# Altium schematic sheet Shutdown_Final.SchDoc(Shutdown_Final)
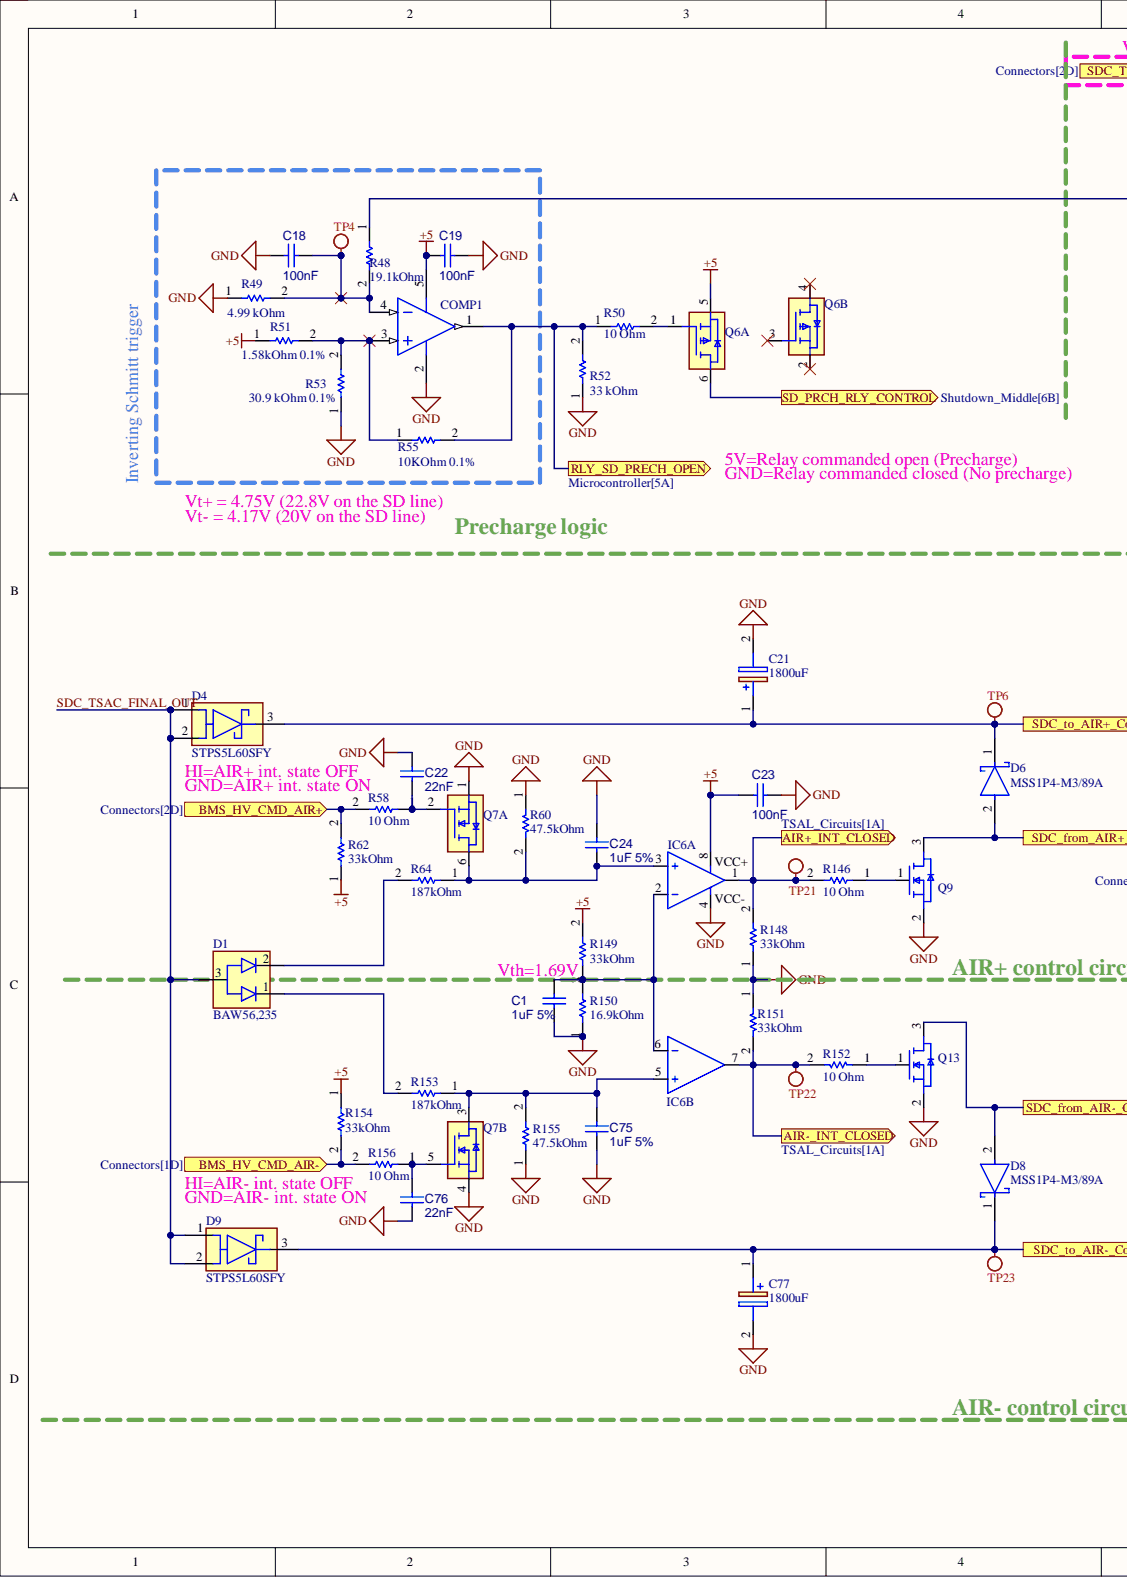
[diagram p0: Shutdown_Final.SchDoc, left]
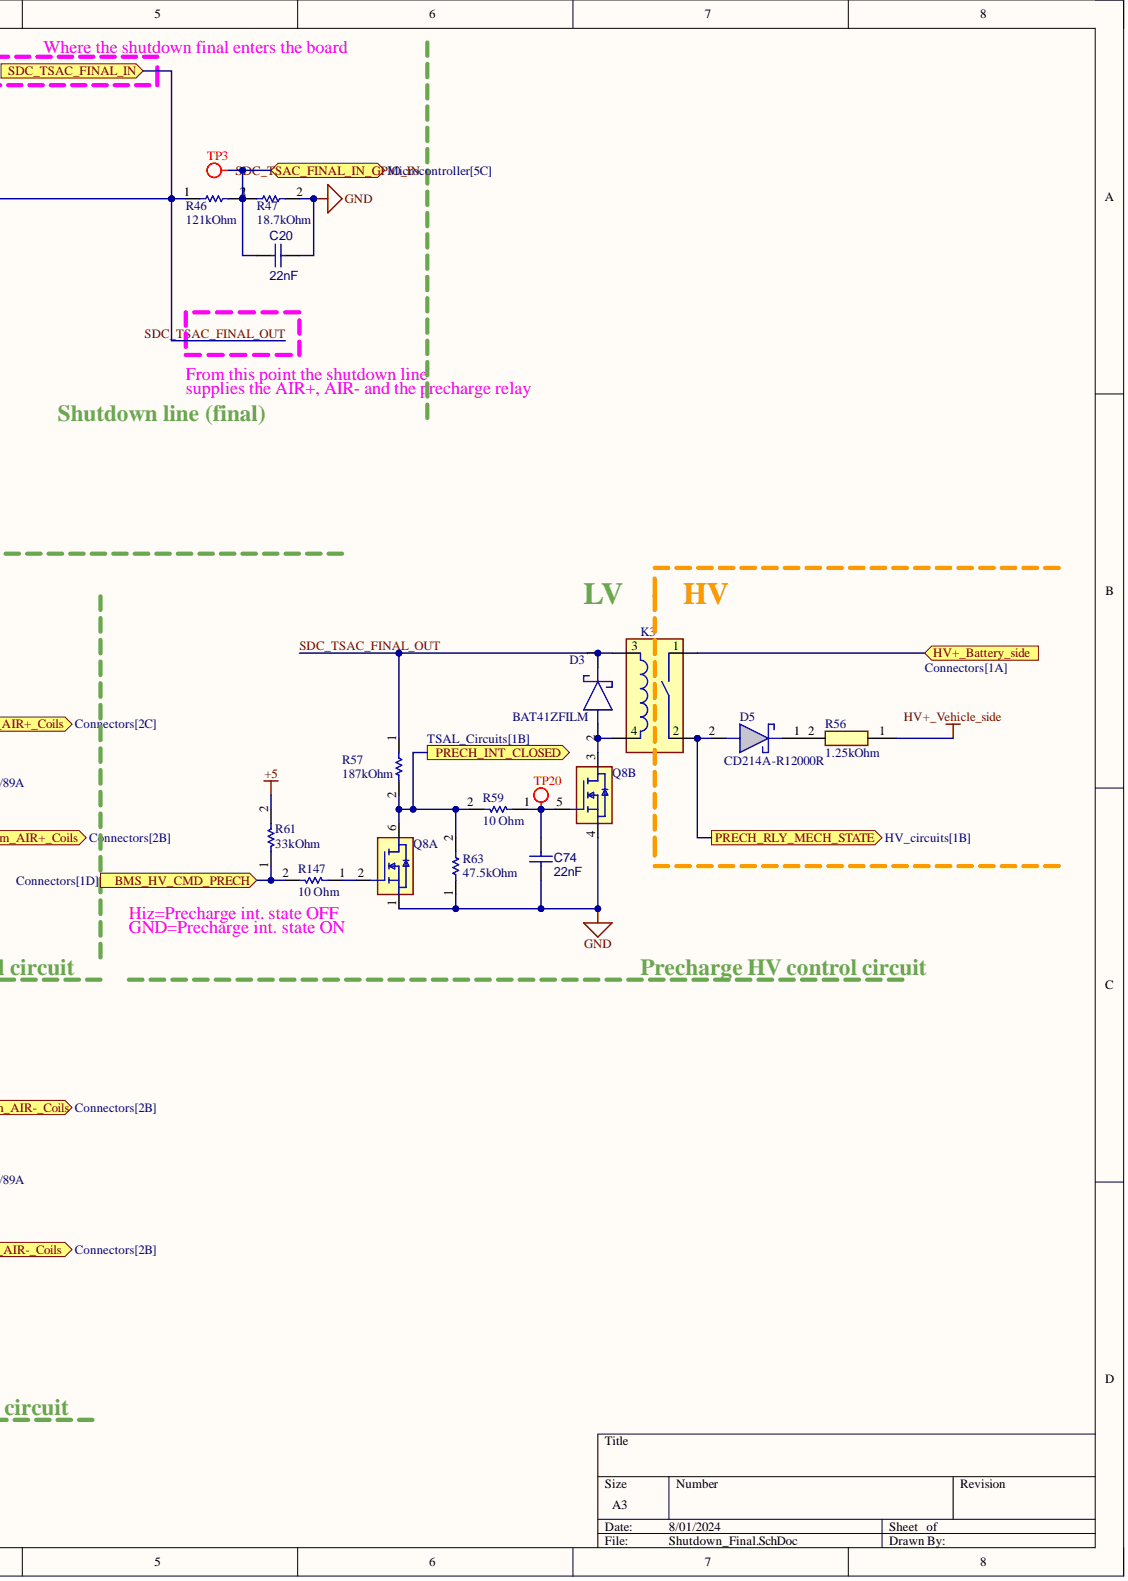
[diagram p1: Shutdown_Final.SchDoc, right]

## Parts
C1  1uF 5%
C18  100nF
C19  100nF
C20  22nF
C21  1800uF
C22  22nF
C23  100nF
C24  1uF 5%
C74  22nF
C75  1uF 5%
C76  22nF
C77  1800uF
COMP1  push-pull Comp  (value not drawn)
D1  BAW56,235
D3  BAT41ZFILM
D4  STPS5L60SFY
D5  CD214A-R12000R
D6  MSS1P4-M3/89A
D8  MSS1P4-M3/89A
D9  STPS5L60SFY
IC6  TS3022IDT  (value not drawn)  (parts A,B)
K3  KT24-1A-40L-THT  (value not drawn)
Q6  SI3993CDV-T1-GE3  (value not drawn)  (parts A,B)
Q7  SI1926DL-T1-GE3  (value not drawn)  (parts A,B)
Q8  SI1926DL-T1-GE3  (value not drawn)  (parts A,B)
Q9  SI2304BDS-T1-E3  (value not drawn)
Q13  SI2304BDS-T1-E3  (value not drawn)
R46  121kOhm
R47  18.7kOhm
R48  19.1kOhm
R49  4.99 kOhm
R50  10 Ohm
R51  1.58kOhm 0.1%
R52  33 kOhm
R53  30.9 kOhm 0.1%
R55  10KOhm 0.1%
R56  1.25kOhm
R57  187kOhm
R58  10 Ohm
R59  10 Ohm
R60  47.5kOhm
R61  33kOhm
R62  33kOhm
R63  47.5kOhm
R64  187kOhm
R146  10 Ohm
R147  10 Ohm
R148  33kOhm
R149  33kOhm
R150  16.9kOhm
R151  33kOhm
R152  10 Ohm
R153  187kOhm
R154  33kOhm
R155  47.5kOhm
R156  10 Ohm
TP3  Test Point  (value not drawn)
TP4  Test Point  (value not drawn)
TP6  Test Point  (value not drawn)
TP20  Test Point  (value not drawn)
TP21  Test Point  (value not drawn)
TP22  Test Point  (value not drawn)
TP23  Test Point  (value not drawn)

## Ports
AIR+_INT_CLOSED, AIR-_INT_CLOSED, BMS_HV_CMD_AIR+, BMS_HV_CMD_AIR-, BMS_HV_CMD_PRECH, HV+_Battery_side, PRECH_INT_CLOSED, PRECH_RLY_MECH_STATE, RLY_SD_PRECH_OPEN, SD_PRCH_RLY_CONTROL, SDC_from_AIR+_Coils, SDC_from_AIR-_Coils, SDC_to_AIR+_Coils, SDC_to_AIR-_Coils, SDC_TSAC_FINAL_IN, SDC_TSAC_FINAL_IN_GPIO_IN

## Nets
+5: C19.2 C23.2 COMP1.5 IC6.8 Q6.5(S1) R51.1 R61.2 R62.1 R149.2 R154.1
GND: C1.2 C18.1 C19.1 C20.2 C21.2 C22.2 C23.1 C24.2 C74.2 C75.2 C76.2 C77.2 COMP1.2 IC6.4 Q7.1 Q7.4 Q8.1 Q8.4 Q9.2 Q13.2 R47.2 R49.1 R52.1 R53.1 R60.1 R63.1 R148.1 R150.1 R151.1 R155.1
HV+_Vehicle_side: R56.1
BMS_HV_CMD_PRECH: R61.1 R147.2 ; port BMS_HV_CMD_PRECH
HV+_Battery_side: K3.1 ; port HV+_Battery_side
PRECH_INT_CLOSED: Q8.6 R57.2 R59.2 R63.2 ; port PRECH_INT_CLOSED
PRECH_RLY_MECH_STATE: D5.2 K3.2 ; port PRECH_RLY_MECH_STATE
RLY_SD_PRECH_OPEN: COMP1.1 R50.1 R52.2 R55.2 ; port RLY_SD_PRECH_OPEN
SD_PRCH_RLY_CONTROL: Q6.6(D1) ; port SD_PRCH_RLY_CONTROL
SDC_TSAC_FINAL_IN_GPIO_IN: C20.1 R46.2 R47.1 TP3.1 ; port SDC_TSAC_FINAL_IN_GPIO_IN
SDC_TSAC_FINAL_OUT: D1.3 D3.1 D4.1 D4.2 D9.1 D9.2 K3.3 R46.1 R48.1 R57.1 ; port SDC_TSAC_FINAL_IN
NetC1_1: C1.1 IC6.2 IC6.6 R149.1 R150.2
NetC18_2: C18.2 COMP1.4 R48.2 R49.2 TP4.1
NetC21_1: C21.1 D4.3 D6.1 TP6.1
NetC22_1: C22.1 Q7.2 R58.1
NetC24_1: C24.1 IC6.3 Q7.6 R60.2 R64.1
NetC74_1: C74.1 Q8.5 R59.1 TP20.1
NetC75_1: C75.1 IC6.5 Q7.3 R153.1 R155.2
NetC76_1: C76.1 Q7.5 R156.1
NetC77_1: C77.1 D8.1 D9.3 TP23.1
NetCOMP1_3: COMP1.3 R51.2 R53.2 R55.1
NetD1_1: D1.1 R153.2
NetD1_2: D1.2 R64.2
NetD3_2: D3.2 K3.4 Q8.3
NetD5_1: D5.1 R56.2
NetD6_2: D6.2 Q9.3
NetD8_2: D8.2 Q13.3
NetIC6_1: IC6.1 R146.2 R148.2 TP21.1
NetIC6_7: IC6.7 R151.2 R152.2 TP22.1
NetQ6_1: Q6.1(G1) R50.2
NetQ8_2: Q8.2 R147.1
NetQ9_1: Q9.1 R146.1
NetQ13_1: Q13.1 R152.1
NetR58_2: R58.2 R62.2
NetR154_2: R154.2 R156.2

Not connected: Q6 2 3 4

## Library data of the parts
C1 C24 C75 = CMP-001-00085-5: C0805C105J4REC7210; Tech: SMD; Component Kind: Standard; ComponentLink1Description: Website; Package: 0805; Library Name: EMEA- Squadra Corse PoliTO-  Politecnico di Torino
C18 C19 C23 = CMP-001-00085-5: VJ0805Y104MXATW1BC; Tech: SMD; Component Kind: Standard; ComponentLink1Description: Website; Package: 0805; Library Name: EMEA- Squadra Corse PoliTO-  Politecnico di Torino
C20 C22 C74 C76 = CMP-001-00085-5: VJ0805Y223JXACW1BC; Tech: SMD; Component Kind: Standard; ComponentLink1Description: Website; Package: 0805; Library Name: EMEA- Squadra Corse PoliTO-  Politecnico di Torino
C21 C77 = CMP-001-00087-2: 35RXA1800MEFC16X20; Component Kind: Standard; Library Name: EMEA- Squadra Corse PoliTO-  Politecnico di Torino
COMP1 = CMP-2000-07318-1: TS3021ICT; Manufacturer: STMicroelectronics; Common Mode Rejection Ratio: 79dB; Dual Supply Voltage (Max): NotRequiredV; Input Bias Current (Typ): 160nA; Input Offset Voltage: 6mV; Number of  Elements: 1; Power Dissipation: 600W; Power Supply Rejection Ratio: 69dB; Power Supply Requirement: Single; Rad Hardened: No; Response Time: 40ns; Single Supply Voltage (Max): 5V; Single Supply Voltage (Min): 2V; Single Supply Voltage (Typ): 3V; Supply Current: 0.115mA; Component Kind: Standard; Datasheet URL: http://www.st.com/content/ccc/resource/technical/document/datasheet/f3/6b/5e/5b/d9/95/43/ee/CD00118313.pdf/files/CD00118313.pdf/jcr:content/translations/en.CD00118313.pdf; Datasheet Version: Rev. 8, 07/2016; Dual Supply Voltage (Min): NotRequiredV; Dual Supply Voltage (Typ): NotRequiredV; Library Name: Altium Content Vault; Manufacturer URL: http://www.st.com/; Mounting: SurfaceMount; Mounting Technology: SurfaceMount; Operating Temp Range: -40Cto125C; Operating Temperature Classification: Automotive; Output Type: Push-Pull; Package Description: 5-Pin Small Outline Transistor, Body 2 x 1.25 mm, Pitch 0.65 mm; Package Reference: SC70-5; Package Type: SC-70; Packaging: TapeandReel; Pin Count: 5; Supply Current (Max): 0.115mA; Technology: BiCMOS
D1 = CMP-036-000019-2: Diodes - General Purpose; Manufacturer_Name: Nexperia; Arrow Part Number: BAW56,235; Arrow Price/Stock: https://www.arrow.com/en/products/baw56235/nexperia?region=europe; Component Kind: Standard; Datasheet Link: https://assets.nexperia.com/documents/data-sheet/BAW56.pdf; Design Item ID: BAW56,235; Height: 1.1mm; Manufacturer_Part_Number: BAW56,235; Mouser Part Number: 771-BAW56235; Mouser Price/Stock: https://www.mouser.co.uk/ProductDetail/Nexperia/BAW56235?qs=me8TqzrmIYVK%2Fa7z8CPW7A%3D%3D; Source: SamacSys.SchLib; Library Name: EMEA- Squadra Corse PoliTO-  Politecnico di Torino
D3 = CMP-036-000017-2: Schottky; Tech: SMD; Component Kind: Standard; Package: SOD-123-2; Library Name: EMEA- Squadra Corse PoliTO-  Politecnico di Torino
D4 D9 = CMP-036-000018-4: STMICROELECTRONICS - STPS5L60SFY - Schottky Rectifier; Tech: SMD; Component Kind: Standard; Package: TO-277A-3; Library Name: EMEA- Squadra Corse PoliTO-  Politecnico di Torino
D5 = CMP-098-000000-2: Schottky Diodes & Rectifiers 1.0A 2000V SMA; Manufacturer_Name: Bourns; Geometry.Height: 1.16mm; Component Kind: Standard; Datasheet Link: https://www.bourns.com/docs/product-datasheets/CD214A-R12000R.pdf; Design Item ID: CD214A-R12000R; Manufacturer_Part_Number: CD214A-R12000R; Mouser Part Number: 652-CD214A-R12000R; Mouser Price/Stock: https://www.mouser.co.uk/ProductDetail/Bourns/CD214A-R12000R?qs=GBLSl2Akirt52tUa7ctYiQ%3D%3D; Source: CD214A-R12000R.SchLib; Library Name: EMEA- Squadra Corse PoliTO-  Politecnico di Torino
D6 D8 = CMP-02539-000019-1: DIODE SCHOTTKY 40V 1A MICROSMP; Manufacturer: Vishay; Capacitance: 50pF; Max Junction Temp: +150°C; Max Surge Forward Current: 25A; Peak Reverse Current: 8.5uA; Value: 50pF; Automotive: No; Category: Diode; Component Kind: Standard; ComponentLink2Description: Datasheet; ComponentLink2URL: http://www.vishay.com/docs/89019/mss1p4.pdf; Device Class L1: Discrete Semiconductors; Device Class L2: Diodes; Device Class L3: Schottky Diodes; Digikey Description: DIODE SCHOTTKY 40V 1A MICROSMP; Footprint Url: http://www.vishay.com/docs/96591/microsmp_do-219ad_fredpt.pdf; Forward Current: 1A; Height: 0.73mm; Lead Free: Yes; Library Name: Altium Content Vault; Manufacturer Part Number: MSS1P4-M3/89A; Max Repetitive Reverse Voltage: 40V; Mouser Description: Schottky Diodes & Rectifiers 1.0 Amp 40 Volt; Octopart: https://octopart.com/mss1p4-m3%2F89a-vishay-42505723; Package: 96591; RoHS: TRUE; Temperature: -55°C to +150°C; Temperature Range High: +150°C; Temperature Range Low: -55°C
IC6 = CMP-030-000040-3: Manufacturer_Name: STMicroelectronics; Arrow Part Number: TS3022IDT; Arrow Price/Stock: https://www.arrow.com/en/products/ts3022idt/stmicroelectronics; Component Kind: Standard; Datasheet Link: http://www.st.com/st-web-ui/static/active/en/resource/technical/document/datasheet/CD00222487.pdf; Description: TS3022IDT; Design Item ID: TS3022IDT; Height: 1.75mm; Manufacturer_Part_Number: TS3022IDT; Mouser Part Number: 511-TS3022IDT; Mouser Price/Stock: https://www.mouser.co.uk/ProductDetail/STMicroelectronics/TS3022IDT?qs=%252BFmDY%2FmH7IbwI8iiFoislw%3D%3D; Source: SamacSys.SchLib; Library Name: EMEA- Squadra Corse PoliTO-  Politecnico di Torino
K3 = CMP-032-000016-3: KT series reed relay; Manufacturer_Name: STANDEXMEDER; Arrow Part Number: KT24-1A-40L-THT; Arrow Price/Stock: https://www.arrow.com/en/products/kt24-1a-40l-tht/standex-meder-electronics?region=nac; Component Kind: Standard; Datasheet Link: https://standexelectronics.com/viewer/pdfjs/web/viewer.php?file=https%3A%2F%2Fstandexelectronics.com%2Fwp-content%2Fuploads%2F2015%2F03%2FKT_V04.pdf; Design Item ID: KT24-1A-40L-THT; Height: 8.7mm; Manufacturer_Part_Number: KT24-1A-40L-THT; Mouser Part Number: 876-KT24-1A-40L-THT; Source: SamacSys.SchLib; Library Name: EMEA- Squadra Corse PoliTO-  Politecnico di Torino
Q6 = CMP-032-000017-2: Dual P-Channel MOSFET; Manufacturer_Name: Vishay; Component Kind: Standard; Datasheet Link: https://www.vishay.com/docs/67331/si3993cdv.pdf; Design Item ID: SI3993CDV-T1-GE3; Height: 1.1mm; Manufacturer_Part_Number: SI3993CDV-T1-GE3; Source: SamacSys.SchLib; Library Name: EMEA- Squadra Corse PoliTO-  Politecnico di Torino
Q7 Q8 = CMP-009-000004-2: MOSFET (N-Channel); Manufacturer_Name: Vishay; Arrow Part Number: SI1926DL-T1-GE3; Arrow Price/Stock: https://www.arrow.com/en/products/si1926dl-t1-ge3/vishay; Component Kind: Standard; Datasheet Link: https://www.vishay.com/docs/73684/si1926dl.pdf; Design Item ID: SI1926DL-T1-GE3; Height: 1.1mm; Manufacturer_Part_Number: SI1926DL-T1-GE3; Mouser Part Number: 78-SI1926DL-T1-GE3; Mouser Price/Stock: https://www.mouser.com/Search/Refine.aspx?Keyword=78-SI1926DL-T1-GE3; Source: SamacSys.SchLib; Library Name: EMEA- Squadra Corse PoliTO-  Politecnico di Torino
Q9 Q13 = CMP-030-000046-3: Manufacturer_Name: Vishay; Arrow Part Number: SI2304BDS-T1-E3; Arrow Price/Stock: https://www.arrow.com/en/products/si2304bds-t1-e3/vishay?region=nac; Component Kind: Standard; Datasheet Link: http://www.vishay.com/docs/72503/si2304bds.pdf; Description: SI2304BDS-T1-E3; Design Item ID: SI2304BDS-T1-E3; Height: 1.12mm; Manufacturer_Part_Number: SI2304BDS-T1-E3; Mouser Part Number: 781-SI2304BDS-T1-E3; Mouser Price/Stock: https://www.mouser.co.uk/ProductDetail/Vishay-Semiconductors/SI2304BDS-T1-E3?qs=jcx%252B0HVgj2YWUCT3FuZcRA%3D%3D; Source: SamacSys.SchLib; Library Name: EMEA- Squadra Corse PoliTO-  Politecnico di Torino
R46 = CMP-009-00179-5: CRCW0603121KFKEA; Tech: SMD; Component Kind: Standard; ComponentLink1Description: Website; Package: 0603; Library Name: EMEA- Squadra Corse PoliTO-  Politecnico di Torino
R47 = CMP-009-00179-5: CRCW060318K7FKEA; Tech: SMD; Component Kind: Standard; ComponentLink1Description: Website; Package: 0603; Library Name: EMEA- Squadra Corse PoliTO-  Politecnico di Torino
R48 = CMP-009-00179-5: RT0603FRE0719K1L; Tech: SMD; Component Kind: Standard; ComponentLink1Description: Website; Package: 0603; Library Name: EMEA- Squadra Corse PoliTO-  Politecnico di Torino
R49 = CMP-009-00179-5: CRCW06034K99FKEAHP; Tech: SMD; Component Kind: Standard; ComponentLink1Description: Website; Package: 0603; Library Name: EMEA- Squadra Corse PoliTO-  Politecnico di Torino
R50 R58 R59 R146 R147 R152 R156 = CMP-009-00179-5: CRCW060310R0FKEAHP; Tech: SMD; Component Kind: Standard; ComponentLink1Description: Website; Package: 0603; Library Name: EMEA- Squadra Corse PoliTO-  Politecnico di Torino
R51 = CMP-009-00179-5: RT0603BRD071K58L; Tech: SMD; Component Kind: Standard; ComponentLink1Description: Website; Package: 0603; Library Name: EMEA- Squadra Corse PoliTO-  Politecnico di Torino
R52 = CMP-009-00179-5: RCS060333K0FKEA; Tech: SMD; Component Kind: Standard; ComponentLink1Description: Website; Package: 0603; Library Name: EMEA- Squadra Corse PoliTO-  Politecnico di Torino
R53 = CMP-009-00179-5: RT0603BRD0730K9L; Tech: SMD; Component Kind: Standard; ComponentLink1Description: Website; Package: 0603; Library Name: EMEA- Squadra Corse PoliTO-  Politecnico di Torino
R55 = CMP-009-00179-5: ERJ-PB3B1002V; Tech: SMD; Component Kind: Standard; ComponentLink1Description: Website; Package: 0603; Library Name: EMEA- Squadra Corse PoliTO-  Politecnico di Torino
R56 = CMP-038-000007-4: CW0101K250JB12; Manufacturer_Name: Vishay; Component Kind: Standard; Datasheet Link: https://www.vishay.com/docs/30215/cw.pdf; Design Item ID: CW0101K250JB12; Manufacturer_Part_Number: CW0101K250JB12; Mouser Part Number: 71-CW0101K250JB12; Mouser Price/Stock: https://www.mouser.com/Search/Refine.aspx?Keyword=71-CW0101K250JB12; Source: SamacSys.SchLib; Library Name: EMEA- Squadra Corse PoliTO-  Politecnico di Torino
R57 R64 R153 = CMP-009-00179-5: CRCW0603187KFKEA; Tech: SMD; Component Kind: Standard; ComponentLink1Description: Website; Package: 0603; Library Name: EMEA- Squadra Corse PoliTO-  Politecnico di Torino
R60 R63 R155 = CMP-009-00179-5: CRCW060347K5FKEA; Tech: SMD; Component Kind: Standard; ComponentLink1Description: Website; Package: 0603; Library Name: EMEA- Squadra Corse PoliTO-  Politecnico di Torino
R61 R62 R148 R149 R151 R154 = CMP-009-00179-5: CRCW060333K0FKEAC; Tech: SMD; Component Kind: Standard; ComponentLink1Description: Website; Package: 0603; Library Name: EMEA- Squadra Corse PoliTO-  Politecnico di Torino
R150 = CMP-009-00179-5: CRCW060316K9FKEA; Tech: SMD; Component Kind: Standard; ComponentLink1Description: Website; Package: 0603; Library Name: EMEA- Squadra Corse PoliTO-  Politecnico di Torino
TP3 TP4 TP6 TP20-TP23 = CMP-002-00071-2: Component Kind: Standard; Description: Test Point; Library Name: EMEA- Squadra Corse PoliTO-  Politecnico di Torino
